# Altium schematic sheet STM32F103C8T6接口&扩展板_接口.SchDoc
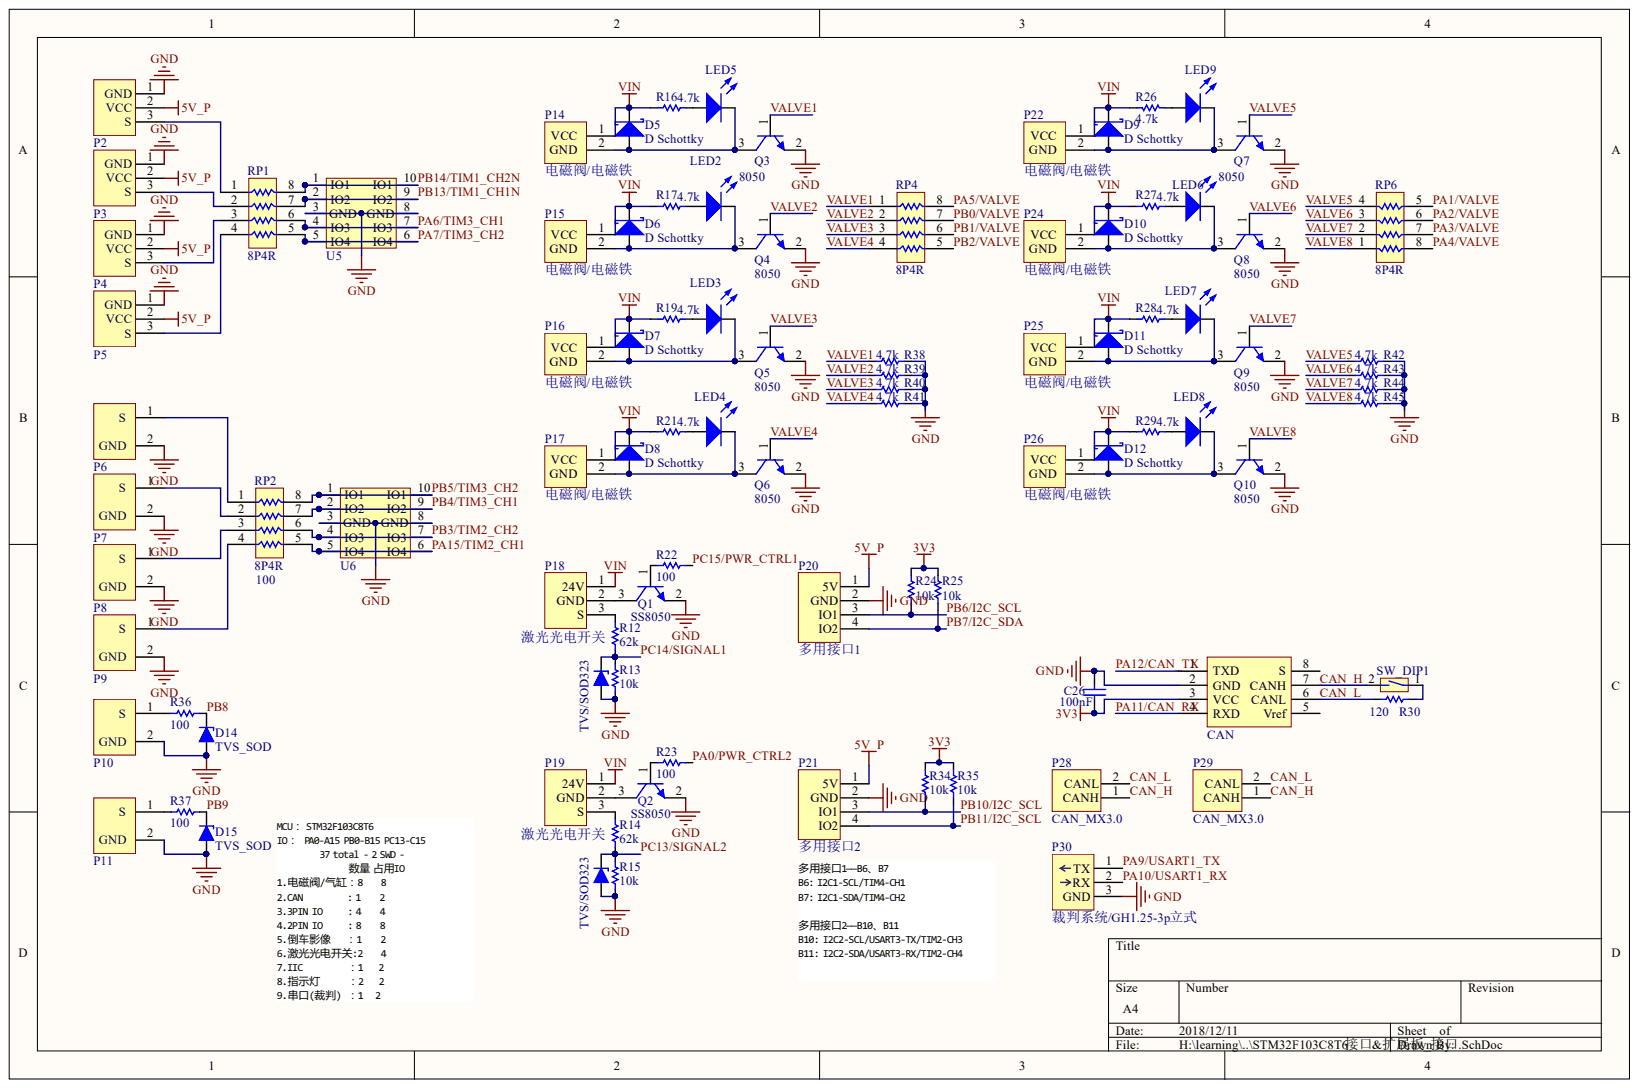
[diagram p0: STM32F103C8T6接口&扩展板_接口.SchDoc]

## Parts
C26  100nF  [0603C]
D3  TVS/SOD323  [SOD-323-]
D4  TVS/SOD323  [SOD-323-]
D5  D Schottky  [SOD-323-]
D6  D Schottky  [SOD-323-]
D7  D Schottky  [SOD-323-]
D8  D Schottky  [SOD-323-]
D9  D Schottky  [SOD-323-]
D10  D Schottky  [SOD-323-]
D11  D Schottky  [SOD-323-]
D12  D Schottky  [SOD-323-]
D14  TVS_SOD  [SOD-323-]
D15  TVS_SOD  [SOD-323-]
LED2  LED_RED  [0603LED_B]  (value not drawn)
LED3  LED_RED  [0603LED_B]  (value not drawn)
LED4  LED_RED  [0603LED_B]  (value not drawn)
LED5  LED_RED  [0603LED_B]  (value not drawn)
LED6  LED_RED  [0603LED_B]  (value not drawn)
LED7  LED_RED  [0603LED_B]  (value not drawn)
LED8  LED_RED  [0603LED_B]  (value not drawn)
LED9  LED_RED  [0603LED_B]  (value not drawn)
P2  3Pin_IO  [HY2.00MM 3x1立式]  (value not drawn)
P3  3Pin_IO  [HY2.00MM 3x1立式]  (value not drawn)
P4  3Pin_IO  [HY2.00MM 3x1立式]  (value not drawn)
P5  3Pin_IO  [HY2.00MM 3x1立式]  (value not drawn)
P6  2pin_IO  [HY2.00MM 2X1_立式]  (value not drawn)
P7  2pin_IO  [HY2.00MM 2X1_立式]  (value not drawn)
P8  2pin_IO  [HY2.00MM 2X1_立式]  (value not drawn)
P9  2pin_IO  [HY2.00MM 2X1_立式]  (value not drawn)
P10  2pin_IO  [HY2.00MM 2X1_立式]  (value not drawn)
P11  2pin_IO  [HY2.00MM 2X1_立式]  (value not drawn)
P14  电磁阀/电磁铁  [HY2.00MM 2X1_立式]
P15  电磁阀/电磁铁  [HY2.00MM 2X1_立式]
P16  电磁阀/电磁铁  [HY2.00MM 2X1_立式]
P17  电磁阀/电磁铁  [HY2.00MM 2X1_立式]
P18  激光光电开关  [HY2.00MM 3x1立式]
P19  激光光电开关  [HY2.00MM 3x1立式]
P20  多用接口1  [HY2.00MM 4X1立式]
P21  多用接口2  [HY2.00MM 4X1立式]
P22  电磁阀/电磁铁  [HY2.00MM 2X1_立式]
P24  电磁阀/电磁铁  [HY2.00MM 2X1_立式]
P25  电磁阀/电磁铁  [HY2.00MM 2X1_立式]
P26  电磁阀/电磁铁  [HY2.00MM 2X1_立式]
P28  CAN_MX3.0  [MX3.0MM 2X1AW]
P29  CAN_MX3.0  [MX3.0MM 2X1AW]
P30  裁判系统/GH1.25-3p立式  [A1254-03AWB]
Q1  SS8050  [SOT-23-3]
Q2  SS8050  [SOT-23-3]
Q3  8050  [SOT-23-3]
Q4  8050  [SOT-23-3]
Q5  8050  [SOT-23-3]
Q6  8050  [SOT-23-3]
Q7  8050  [SOT-23-3]
Q8  8050  [SOT-23-3]
Q9  8050  [SOT-23-3]
Q10  8050  [SOT-23-3]
R12  62k  [0603R]
R13  10k  [0603R]
R14  62k  [0603R]
R15  10k  [0603R]
R16  4.7k  [0603R]  (value not drawn)
R17  4.7k  [0603R]  (value not drawn)
R19  4.7k  [0603R]  (value not drawn)
R21  4.7k  [0603R]  (value not drawn)
R22  100  [0603R]
R23  100  [0603R]
R24  10k  [0603R]
R25  10k  [0603R]
R26  4.7k  [0603R]
R27  4.7k  [0603R]  (value not drawn)
R28  4.7k  [0603R]  (value not drawn)
R29  4.7k  [0603R]  (value not drawn)
R30  120  [0603R]
R34  10k  [0603R]
R35  10k  [0603R]
R36  100  [0603R]
R37  100  [0603R]
R38  4.7k  [0603R]
R39  4.7k  [0603R]
R40  4.7k  [0603R]
R41  4.7k  [0603R]
R42  4.7k  [0603R]
R43  4.7k  [0603R]
R44  4.7k  [0603R]
R45  4.7k  [0603R]
RP1  8P4R  [RES-8P4R-0603]
RP2  8P4R  [RES-8P4R-0603]
RP4  8P4R  [RES-8P4R-0603]
RP6  8P4R  [RES-8P4R-0603]
S3  SW_DIP1  [MSK-12C01-07]
U5  ESD-4channels_type2  [DQA0010A]  (value not drawn)
U6  ESD-4channels_type2  [DQA0010A]  (value not drawn)
U8  CAN  [SOT-23-8]

## Nets
3V3: C26.1 R24.2 R25.2 R34.2 R35.2 U8.3(VCC)
5V_P: P2.2 P3.2 P4.2 P5.2 P20.1(5V) P21.1(5V)
GND: C26.2 D3.1 D4.1 D14.1 D15.1 P2.1 P3.1 P4.1 P5.1 P6.2 P7.2 P8.2 P9.2 P10.2 P11.2 P20.2(GND) P21.2(GND) P30.3 Q1.2 Q2.2 Q3.2 Q4.2 Q5.2 Q6.2 Q7.2 Q8.2 Q9.2 Q10.2 R13.1 R15.1 R38.2 R39.2 R40.2 R41.2 R42.2 R43.2 R44.2 R45.2 U5.3(GND) U5.8(GND) U6.3(GND) U6.8(GND) U8.2(GND)
VIN: D5.2 D6.2 D7.2 D8.2 D9.2 D10.2 D11.2 D12.2 P14.1 P15.1 P16.1 P17.1 P18.1 P19.1 P22.1 P24.1 P25.1 P26.1 R16.1 R17.1 R19.1 R21.1 R26.2 R27.1 R28.1 R29.1
CAN_H: P28.1 P29.1 S3.2 U8.7(CANH)
CAN_L: P28.2 P29.2 R30.1 U8.6(CANL)
PA0/PWR_CTRL2: R23.2
PA1/VALVE: RP6.5
PA2/VALVE: RP6.6
PA3/VALVE: RP6.7
PA4/VALVE: RP6.8
PA5/VALVE: RP4.8
PA6/TIM3_CH1: RP1.6 U5.4(IO3) U5.7(IO3)
PA7/TIM3_CH2: RP1.5 U5.5(IO4) U5.6(IO4)
PA9/USART1_TX: P30.1
PA10/USART1_RX: P30.2
PA11/CAN_RX: U8.4(RXD)
PA12/CAN_TX: U8.1(TXD)
PA15/TIM2_CH1: RP2.5 U6.5(IO4) U6.6(IO4)
PB0/VALVE: RP4.7
PB1/VALVE: RP4.6
PB2/VALVE: RP4.5
PB3/TIM2_CH2: RP2.6 U6.4(IO3) U6.7(IO3)
PB4/TIM3_CH1: RP2.7 U6.2(IO2) U6.9(IO2)
PB5/TIM3_CH2: RP2.8 U6.1(IO1) U6.10(IO1)
PB6/I2C_SCL: P20.3(IO1) R24.1
PB7/I2C_SDA: P20.4(IO2) R25.1
PB8: D14.2 R36.1
PB9: D15.2 R37.1
PB10/I2C_SCL: P21.3(IO1) R34.1
PB11/I2C_SCL: P21.4(IO2) R35.1
PB13/TIM1_CH1N: RP1.7 U5.2(IO2) U5.9(IO2)
PB14/TIM1_CH2N: RP1.8 U5.1(IO1) U5.10(IO1)
PC13/SIGNAL2: D4.2 R14.1 R15.2
PC14/SIGNAL1: D3.2 R12.1 R13.2
PC15/PWR_CTRL1: R22.2
VALVE1: Q3.1 R38.1 RP4.1
VALVE2: Q4.1 R39.1 RP4.2
VALVE3: Q5.1 R40.1 RP4.3
VALVE4: Q6.1 R41.1 RP4.4
VALVE5: Q7.1 R42.1 RP6.4
VALVE6: Q8.1 R43.1 RP6.3
VALVE7: Q9.1 R44.1 RP6.2
VALVE8: Q10.1 R45.1 RP6.1
NetD5_1: D5.1 LED5.2 P14.2 Q3.3
NetD6_1: D6.1 LED2.2 P15.2 Q4.3
NetD7_1: D7.1 LED3.2 P16.2 Q5.3
NetD8_1: D8.1 LED4.2 P17.2 Q6.3
NetD9_1: D9.1 LED9.2 P22.2 Q7.3
NetD10_1: D10.1 LED6.2 P24.2 Q8.3
NetD11_1: D11.1 LED7.2 P25.2 Q9.3
NetD12_1: D12.1 LED8.2 P26.2 Q10.3
NetLED2_1: LED2.1 R17.2
NetLED3_1: LED3.1 R19.2
NetLED4_1: LED4.1 R21.2
NetLED5_1: LED5.1 R16.2
NetLED6_1: LED6.1 R27.2
NetLED7_1: LED7.1 R28.2
NetLED8_1: LED8.1 R29.2
NetLED9_1: LED9.1 R26.1
NetP2_3: P2.3 RP1.1
NetP3_3: P3.3 RP1.2
NetP4_3: P4.3 RP1.3
NetP5_3: P5.3 RP1.4
NetP6_1: P6.1 RP2.1
NetP7_1: P7.1 RP2.2
NetP8_1: P8.1 RP2.3
NetP9_1: P9.1 RP2.4
NetP10_1: P10.1 R36.2
NetP11_1: P11.1 R37.2
NetP18_2: P18.2 Q1.3
NetP18_3: P18.3 R12.2
NetP19_2: P19.2 Q2.3
NetP19_3: P19.3 R14.2
NetQ1_1: Q1.1 R22.1
NetQ2_1: Q2.1 R23.1
NetR30_2: R30.2 S3.1

Not connected: U8 5(Vref) 8(S)

## Library data of the parts
C26 = Cap: Capacitor; Value: 100pF; Component Kind: Standard; LatestRevisionDate: 17-Jul-2002; LatestRevisionNote: Re-released for DXP Platform.; Library Name: MyComponents_v2.4.IntLib; PackageDescription: Radial Cap, Thru-Hole; 2 Leads; 0.3 in Pin Spacing; PackageReference: RAD-0.3; Published: 8-Jun-2000; Signal Integrity: Cap; Simulation: CAP; Publisher: Altium Limited
D3 D4 = TVS_SOD: Component Kind: Standard; Library Name: MyComponents_v2.4.IntLib
D5-D12 = D Schottky: Schottky Diode; Code_JEDEC: DO-214-AA; Component Kind: Standard; LatestRevisionDate: 17-Jul-2002; LatestRevisionNote: Re-released for DXP Platform.; Library Name: MyComponents_v2.5.IntLib; PackageDescription: DO-214-AA/SMB; 2 C-Bend Leads; Body 5.3 x 3.6 mm, inc. leads (LxW); PackageReference: SMB; Published: 8-Jun-2000; Signal Integrity: D Schottky; Simulation: SKYDIODE; Publisher: Altium Limited
LED2-LED9 = LED: Typical RED, GREEN, YELLOW, AMBER GaAs LED; Component Kind: Standard; LatestRevisionDate: 17-Jul-2002; LatestRevisionNote: Re-released for DXP Platform.; Library Name: MyComponents_v2.5.IntLib; PackageDescription: SM LED; 2 Flat Leads; PackageReference: 3.2X1.6X1.1; PackageVersion: Feb-2002; Published: 8-Jun-2000; Simulation: LED2; Publisher: Altium Limited
P2-P5 = 3Pin_IO: 3pin input/output. V+S+G; Component Kind: Standard; Library Name: MyComponents_v2.4.IntLib
P20 P21 = 倒车影像: Component Kind: Standard; Library Name: MyComponents_v2.5.IntLib
P30 = UART_3pin: Component Kind: Standard; Library Name: MyComponents_v2.5.IntLib
Q1-Q10 = NPN: NPN Bipolar Transistor; Code_JEDEC: TO-226-AA; Component Kind: Standard; LatestRevisionDate: 17-Jul-2002; LatestRevisionNote: Re-released for DXP Platform.; PackageDescription: TO, Flat Index; 3 In-Line, Axial Leads; Body Dia. 4.8mm; Leads 0.55 x 0.51 mm (max); PackageReference: TO-226-AA; Published: 8-Jun-2000; Signal Integrity: NPN; Simulation: NPN; Publisher: Altium Limited
  Q1 Q2: Library Name: MyComponents_v2.4.IntLib
  Q3-Q10: Library Name: MyComponents_v2.5.IntLib
R12-R17 R19 R21-R30 R34-R45 = Res1: Resistor; Value: 1K; Component Kind: Standard; LatestRevisionDate: 17-Jul-2002; LatestRevisionNote: Re-released for DXP Platform.; PackageDescription: Axial Device, Thru-Hole; 2 Leads; 0.3 in Pin Spacing; PackageReference: AXIAL-0.3; Published: 8-Jun-2000; Signal Integrity: Res; Simulation: RESISTOR; Publisher: Altium Limited
  R12-R15 R22-R25 R30 R34 R35: Library Name: MyComponents_v2.4.IntLib
  R16 R17 R19 R21 R26-R29 R36-R45: Library Name: MyComponents_v2.5.IntLib
RP1 RP2 RP4 RP6 = Res-8P4R: Component Kind: Standard; Description: 8P4R; Library Name: MyComponents_v2.5.IntLib
  RP2: : 100
S3 = SW_DIP 1: Component Kind: Standard; Library Name: MyComponents_v2.4.IntLib
U5 U6 = ESD-4channels_type2: Imported; Manufacturer: Texas Instruments; PartNumber: TPD4E05U06DQAR; CompType: IC; PIN_COUNT: 10; Component Kind: Standard; Library Name: MyComponents_v2.5.IntLib; MountType: SMT; PackageReference: DQA0010A; RefDes: RefDes; RoHS: Y; TYPE: TYPE; Value: Value
D14 D15 = TVS_SOD: Component Kind: Standard; Library Name: MyComponents_v2.5.IntLib
P6-P11 = 2pin_IO: Component Kind: Standard; Library Name: MyComponents_v2.4.IntLib
P14-P17 P22 P24-P26 = 电磁阀/电磁铁: Component Kind: Standard; Library Name: MyComponents_v2.5.IntLib
P18 P19 = 激光光电开关: Component Kind: Standard; Library Name: MyComponents_v2.4.IntLib
P28 P29 = CAN_MX3.0: Component Kind: Standard; Library Name: MyComponents_v2.5.IntLib
U8 = CAN: Component Kind: Standard; Library Name: MyComponents_v2.4.IntLib
